# Altium schematic sheet Power Schematic.SchDoc("Power Schematic")
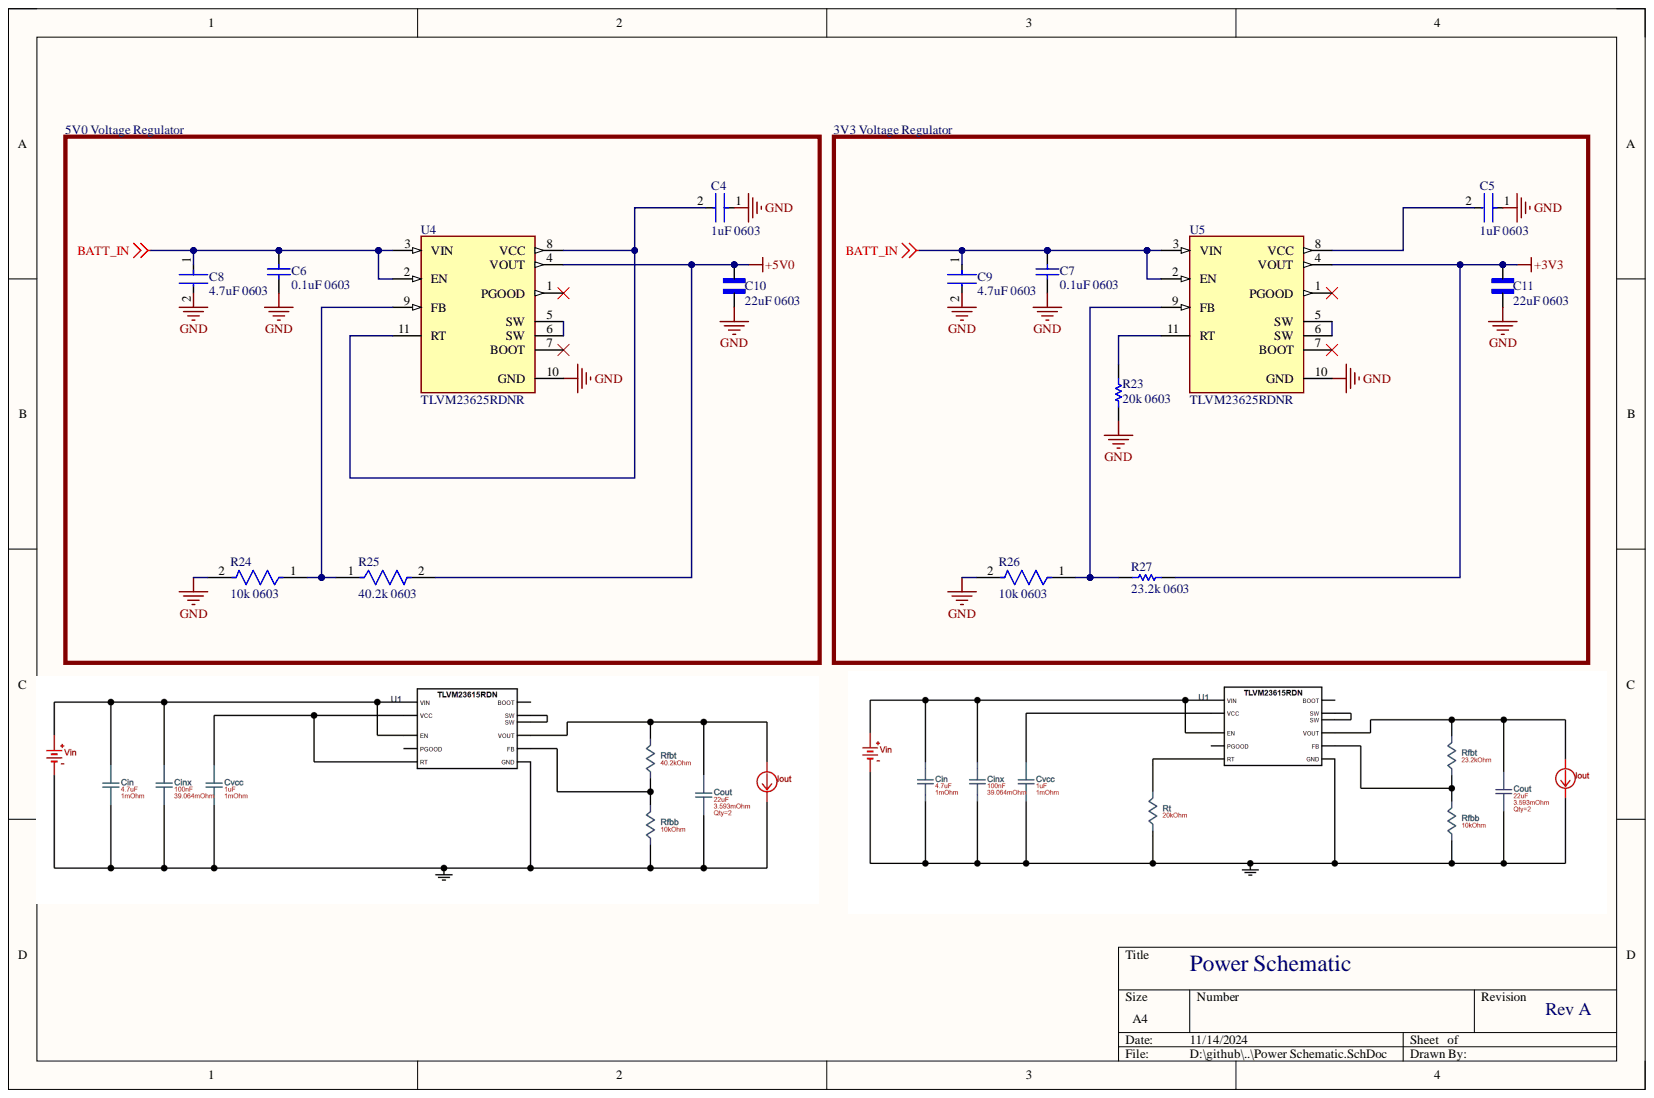
[diagram p0: Power Schematic.SchDoc]

## Parts
C4  1uF 0603  value 1 uF  [FP-CC0603-DA-MFG]
C5  1uF 0603  value 1 uF  [FP-CC0603-DA-MFG]
C6  0.1uF 0603  Package Reference: 0603  [CAPC1608X90X40LL10T20]
C7  0.1uF 0603  Package Reference: 0603  [CAPC1608X90X40LL10T20]
C8  4.7uF 0603  value 4.7 uF  [FP-CC0603-DA-MFG]
C9  4.7uF 0603  value 4.7 uF  [FP-CC0603-DA-MFG]
C10  22uF 0603  [CAPC1608X90]
C11  22uF 0603  [CAPC1608X90]
R23  20k 0603  [RESC1608X55X25NL10T15]
R24  10k 0603  Case Code (Imperial): 0603  [FP-RC0603-0_55-IPC_C]
R25  40.2k 0603  Case Code (Imperial): 0603  [FP-RC0603-0_55-IPC_C]
R26  10k 0603  Case Code (Imperial): 0603  [FP-RC0603-0_55-IPC_C]
R27  23.2k 0603  [RESC1608X55X25NL10T15]
U4  TLVM23625RDNR  [CONV_TLVM23625RDNR]
U5  TLVM23625RDNR  [CONV_TLVM23625RDNR]

## Nets
+3V3: C11.1 R27.2 U5.4(VOUT)
+5V0: C10.1 R25.2 U4.4(VOUT)
BATT_IN: C6.2 C7.2 C8.1 C9.1 U4.2(EN) U4.3(VIN) U5.2(EN) U5.3(VIN)
GND: C4.1 C5.1 C6.1 C7.1 C8.2 C9.2 C10.2 C11.2 R23.1 R24.2 R26.2 U4.10(GND) U5.10(GND)
NetC4_2: C4.2 U4.8(VCC) U4.11(RT)
NetC5_2: C5.2 U5.8(VCC)
NetR23_2: R23.2 U5.11(RT)
NetR24_1: R24.1 R25.1 U4.9(FB)
NetR26_1: R26.1 R27.1 U5.9(FB)
NetU4_5: U4.5(SW) U4.6(SW)
NetU5_5: U5.5(SW) U5.6(SW)

Not connected: U4 1(PGOOD) 7(BOOT); U5 1(PGOOD) 7(BOOT)

## Library data of the parts
C4 C5 = CMP-03422-000612-1: CAP CER 1UF 25V X5R 0603; Manufacturer: YAGEO; Manufacturer Part Number: CC0603KRX5R8BB105; Material: Ceramic; Temperature Coefficient: 15%; Tolerance: 0.1; Automotive: No; Capacitance: 1 uF; Category: Cap; Component Kind: Standard; ComponentLink2Description: Datasheet; ComponentLink2URL: http://www.yageo.com/documents/recent/UPY-GPHC_X7R_6.3V-to-50V_18.pdf; Device Class L1: Passive Components; Device Class L2: Capacitors; Device Class L3: Ceramic Capacitors; Digikey Description: CAP CER 1UF 25V X5R 0603; Footprint Url: http://www.yageo.com/exep/pages/download/literatures/UPY-C_GEN_24.pdf; Height: 0.9mm; Lead Free: Yes; Library Name: Altium Content Vault; Mouser Description: Multilayer Ceramic Capacitors MLCC - SMD/SMT 1.0uF 25V X5R 10%; Octopart: https://octopart.com/cc0603krx5r8bb105-yageo-9292811; Package: CC0603-DA; RoHS: TRUE; Temperature: -55°C to +125°C; Temperature Characteristic: X5R; Temperature Range High: +125°C; Temperature Range Low: -55°C; Value: 1 uF; Voltage: 25 V; Voltage Rating: 25 V
C6 C7 = CMP-1035-02594-2: Chip Capacitor, 100 nF, - 20% to + 80%, 50 V, -30 to 85 degC, 0603 (1608 Metric), RoHS, Tape and Reel; Manufacturer: Yageo; Capacitance: 0.1µF; Featured Product: CommodityMultilayerCeramicCapacitors–CCSeries; Kits: CC060300000000000-ND-MLCC0603SAMPLEKIT; Online Catalog: CCSeries; Other Names: 311-1343-1; Product Training Modules: MultilayerCeramicCapacitors; Temperature Coefficient: Y5V(F); Tolerance: -20%,+80%; Voltage - Rated: 50V; Applications: GeneralPurpose; Category: Capacitors; Component Kind: Standard; Datasheet URL: https://datasheet.ciiva.com/17434/upy-gphc-y5v-6-3v-to-50v-5-17434523.pdf; Datasheet Version: Rev. 5, 07/2010; Family: CeramicCapacitors; Features: -; Height - Seated (Max): -; Lead Spacing: -; Lead Style: -; Library Name: Altium Content Vault; Manufacturer URL: http://www.yageo.com/; Mounting Technology: SurfaceMount; Mounting Type: SurfaceMount,MLCC; Operating Temperature: -30°C~85°C; Package / Case: 0603(1608Metric); Package Description: 2-Pin Surface Mount Device, Body 1.6 x 0.8 mm; Packaging: CutTape(CT); Ratings: -; Series: CC; Size / Dimension: 0.063"Lx0.031"W(1.60mmx0.80mm); Standard Package: 1; Thickness (Max): 0.035"(0.90mm)
C8 C9 = CMP-03422-001236-1: CAP CER 4.7UF 16V X5R 0603; Manufacturer: YAGEO; Manufacturer Part Number: CC0603KRX5R7BB475; Material: Ceramic; Temperature Coefficient: 15%; Tolerance: 0.1; Automotive: No; Capacitance: 4.7 uF; Category: Cap; Component Kind: Standard; ComponentLink2Description: Datasheet; ComponentLink2URL: http://www.yageo.com/documents/recent/UPY-GPHC_X7R_6.3V-to-50V_18.pdf; Device Class L1: Passive Components; Device Class L2: Capacitors; Device Class L3: Ceramic Capacitors; Digikey Description: CAP CER 4.7UF 16V X5R 0603; Footprint Url: http://www.yageo.com/exep/pages/download/literatures/UPY-C_GEN_24.pdf; Height: 0.9mm; Lead Free: Yes; Library Name: Altium Content Vault; Mouser Description: Multilayer Ceramic Capacitors MLCC - SMD/SMT 4.7uF 16V X5R 10%; Octopart: https://octopart.com/cc0603krx5r7bb475-yageo-34978295; Package: CC0603-DA; RoHS: TRUE; Temperature: -55°C to +125°C; Temperature Characteristic: X5R; Temperature Range High: +125°C; Temperature Range Low: -55°C; Value: 4.7 uF; Voltage: 16 V; Voltage Rating: 16 V
C10 C11 = CC0603MRX5R6BB226: Cap Ceramic 22uF 10V X5R 20% SMD 0603 85Â°C Paper T/R; MF: {'manufacturer': u'Yageo'}; Availability: Unavailable; Component Kind: Standard; MP: CC0603MRX5R6BB226; Package: None; Price: None
R23 = CMP-2000-04254-1: Manufacturer: Yageo; Part Number: RC0603JR-0720RL; Component Kind: Standard; Library Name: Altium Content Vault
R24 R26 = CMP-2100-03664-2: RES 10K OHM 1% 1/10W 0603; Max Power Dissipation: 100mW; Resistance: 10kR; Temperature Coefficient: 100ppm/°C; Voltage Rating: 75V; Voltage Rating (DC): 50V; Case Code (Metric): 1608; Case/Package: 0603; Component Kind: Standard; Construction: Rectangular; Depth: 0.8mm; Features: Moisture Resistant; Height: 0.45mm; Lead Free: Lead Free; Lead Pitch: 0.3mm; Length: 1.6mm; Library Name: Altium Content Vault; Max Operating Temperature: 155°C; Military Standard: Not; Min Operating Temperature: -55°C; Number of Pins: 2; Number of Terminations: 2; Packaging: Tape and Reel; Power Rating: 100mW; Resistance Tolerance: 1%; Resistor Type: Thick Film; RoHS Compliant: Yes; Series: RC; Size Code: 0603; Termination: SMD/SMT; Tolerance: 1%; Weight: 7.1E-05oz; Width: 0.8mm
R25 = CMP-03412-037816-1: RES 40.2K OHM 1% 1/10W 0603; Max Power Dissipation: 100mW; Resistance: 40.2kR; Temperature Coefficient: 100ppm/°C; Voltage Rating: 50V; Working Voltage: 75V; Case Code (Metric): 1608; Case/Package: 0603; Component Kind: Standard; Construction: Rectangular; Depth: 0.8mm; Features: Moisture Resistant; Height: 0.45mm; Lead Free: Lead Free; Length: 1.6mm; Library Name: Altium Content Vault; Max Operating Temperature: 155°C; Military Standard: Not; Min Operating Temperature: -55°C; Mount: Surface Mount; Number of Terminations: 2; Packaging: Tape and Reel; Power Rating: 100mW; Resistor Type: Thick Film; RoHS Compliant: Yes; Series: RC; Technology: METAL OXIDE FILM; Termination: SMD/SMT; Tolerance: 1%; Voltage Rating (DC): 50V; Width: 0.8mm
R27 = CMP-2000-03962-1: Manufacturer: Yageo; Part Number: RC0603FR-0723K2L; Component Kind: Standard; Library Name: Altium Content Vault
U4 U5 = TLVM23625RDNR: MF: {'manufacturer': u'Texas Instruments'}; Availability: Unavailable; Component Kind: Standard; MP: TLVM23625RDNR; Package: None; Price: None
